# Altium schematic sheet Power Input and Protection Rev 5.SchDoc("Power Input and Protection Rev 5")
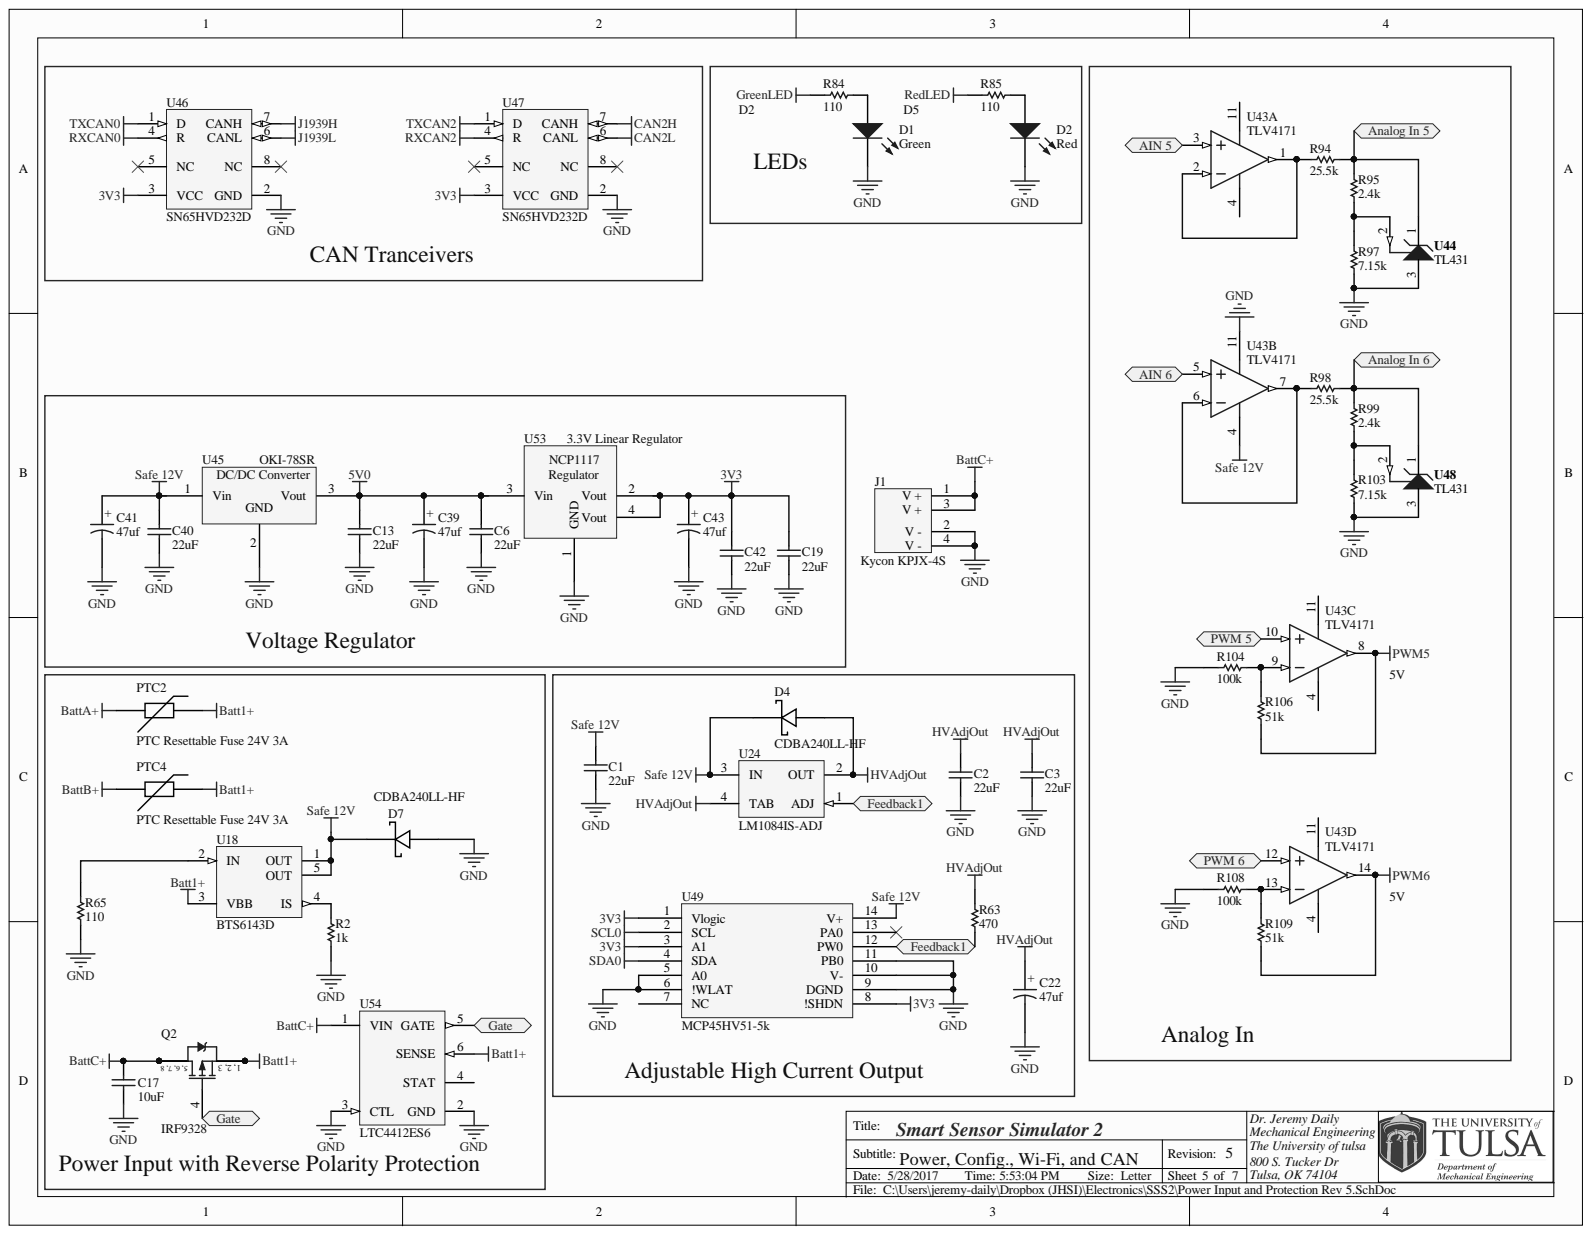
[diagram p0: Power Input and Protection Rev 5.SchDoc]

## Parts
C1  22uF  [1206]
C2  22uF  [1206]
C3  22uF  [1206]
C6  22uF  [1206]
C13  22uF  [1206]
C17  10uF  [0603]
C19  22uF  [1206]
C22  47uf  [Elec. Cap 2.5 x 6.3mm]
C39  47uf  [Elec. Cap 2.5 x 6.3mm]
C40  22uF  [1206]
C41  47uf  [Elec. Cap 2.5 x 6.3mm]
C42  22uF  [1206]
C43  47uf  [Elec. Cap 2.5 x 6.3mm]
D1  Green  [0805 Polarized]
D2  Red  [0805 Polarized]
D4  CDBA240LL-HF  [SMA with A and K]
D7  CDBA240LL-HF  [SMA with A and K]
J1  Kycon KPJX-4S  Manufacturer: Kycon  [KPJX-4S-S]
PTC2  PTC Resettable Fuse 24V 3A  [2920]
PTC4  PTC Resettable Fuse 24V 3A  [2920]
Q2  IRF9328  [SOIC-8]
R2  1k  [0603]
R63  470  [0603]
R65  110  [0603]
R84  110  [0603]
R85  110  [0603]
R94  25.5k  [0603]
R95  2.4k  [0603]
R97  7.15k  [0603]
R98  25.5k  [0603]
R99  2.4k  [0603]
R103  7.15k  [0603]
R104  100k  [0603]
R106  51k  [0603]
R108  100k  [0603]
R109  51k  [0603]
U18  BTS6143D  [INF-PG-TO252-5-11_N]
U24  LM1084IS-ADJ  [TS3B_M]
U43  TLV4171  [TI-PW14_M]  (parts A,B,C,D)
U44  TL431  [TI-DBZ3_N]
U45  OKI-78SR  [78xx]
U46  SN65HVD232D  [SN65HVD232]
U47  SN65HVD232D  [SN65HVD232]
U48  TL431  [TI-DBZ3_N]
U49  MCP45HV51-5k  [TSSOP-ST14_M]
U53  3.3V Linear Regulator  [SOT-223]
U54  LTC4412ES6  [LT-S6-6-TSOT-23_M]

## Ports
AIN 5, AIN 6, ANALOG IN 5, ANALOG IN 6, FEEDBACK1, GATE, PWM 5, PWM 6

## Nets
3V3: C19.2 C42.2 C43.1 U46.3(VCC) U47.3(VCC) U49.1(Vlogic) U49.3(A1) U49.8(!SHDN) U53.2(Vout) U53.4(Vout)
5V0: C6.2 C13.2 C39.1 U45.3 U53.3(Vin)
BATT1+: PTC2.2 PTC4.2 Q2.1 Q2.2 Q2.3 U18.3(VBB) U54.6(SENSE)
BATTA+: PTC2.1
BATTB+: PTC4.1
BATTC+: C17.1 J1.1(V_+) J1.3(V_+) Q2.5 Q2.6 Q2.7 Q2.8 U54.1(VIN)
CAN2H: U47.7(CANH)
CAN2L: U47.6(CANL)
GND: C1.1 C2.1 C3.1 C6.1 C13.1 C17.2 C19.1 C22.2 C39.2 C40.1 C41.2 C42.1 C43.2 D1.2 D2.2 D7.1 J1.2(V_-) J1.4(V_-) R2.1 R65.1 R97.1 R103.1 R104.2 R108.2 U43.11 U44.3 U45.2 U46.2(GND) U47.2(GND) U48.3 U49.5(A0) U49.6(!WLAT) U49.9(DGND) U49.10(V-) U49.11(PB0) U53.1(GND) U54.2(GND) U54.3(CTL)
GREENLED: R84.2
HVADJOUT: C2.2 C3.2 C22.1 D4.1 R63.1 U24.2(OUT) U24.4(TAB)
J1939H: U46.7(CANH)
J1939L: U46.6(CANL)
PWM5: R106.2 U43.8
PWM6: R109.2 U43.14
REDLED: R85.2
RXCAN0: U46.4(R)
RXCAN2: U47.4(R)
SAFE 12V: C1.2 C40.2 C41.1 D4.2 D7.2 U18.1(OUT) U18.5(OUT) U24.3(IN) U43.4 U45.1 U49.14(V+)
SCL0: U49.2(SCL)
SDA0: U49.4(SDA)
TXCAN0: U46.1(D)
TXCAN2: U47.1(D)
AIN 5: U43.3 ; port AIN 5
AIN 6: U43.5 ; port AIN 6
PWM 5: U43.10 ; port PWM 5
PWM 6: U43.12 ; port PWM 6
NetD1_1: D1.1 R84.1
NetD2_1: D2.1 R85.1
NetQ2_4: Q2.4 U54.5(GATE) ; port GATE
NetR2_2: R2.2 U18.4(IS)
NetR63_2: R63.2 U24.1(ADJ) U49.12(PW0) ; port FEEDBACK1
NetR65_2: R65.2 U18.2(IN)
NetR94_1: R94.1 U43.1 U43.2
NetR94_2: R94.2 R95.2 U44.1 ; port ANALOG IN 5
NetR95_1: R95.1 R97.2 U44.2
NetR98_1: R98.1 U43.6 U43.7
NetR98_2: R98.2 R99.2 U48.1 ; port ANALOG IN 6
NetR99_1: R99.1 R103.2 U48.2
NetR104_1: R104.1 R106.1 U43.9
NetR108_1: R108.1 R109.1 U43.13

Not connected: U46 5(NC) 8(NC); U47 5(NC) 8(NC); U49 7(NC) 13(PA0); U54 4(STAT)

## Library data of the parts
C1-C3 C6 C13 C19 C40 C42 = Cap: 22µF ±20% 25V Ceramic Capacitor X5R 1206 (3216 Metric); Manufacturer: Murata Electronics North America; PartNumber: GRT31CR61E226ME01L; Component Kind: Standard; Library Name: SSS Integrated Library.IntLib; Signal Integrity: Cap; Simulation: CAP; Supplier 1: Digi-Key; Supplier Part Number 1: 490-12451-1-ND
C17 = Cap: 10µF ±20% 25V Ceramic Capacitor X5R 0603 (1608 Metric); Manufacturer: Murata Electronics North America; PartNumber: GRT188R61E106ME13D; Component Kind: Standard; Library Name: SSS Integrated Library.IntLib; Signal Integrity: Cap; Simulation: CAP; Supplier 1: Digi-Key; Supplier Part Number 1: 490-12323-1-ND
C22 C39 C41 C43 = Electrolytic Cap: 47µF 50V Aluminum Capacitors Radial, Can 5000 Hrs @ 105°C; Manufacturer: Panasonic Electronic Components; PartNumber: EEU-EB1H470S; Component Kind: Standard; Library Name: SSS Integrated Library.IntLib; Supplier 1: Digi-Key; Supplier Part Number 1: P13464-ND
D1 = LED - Green: Green 570nm LED Indication - Discrete 2.2V 0805 (2012 Metric); Manufacturer: OSRAM Opto Semiconductors Inc.; PartNumber: LG R971-KN-1; Component Kind: Standard; Library Name: SSS Integrated Library.IntLib; Supplier 1: Digi-Key; Supplier Part Number 1: 475-1410-1-ND
D2 = LED - Red: Red 633nm LED Indication - Discrete 2V 0805 (2012 Metric); Manufacturer: OSRAM Opto Semiconductors Inc.; PartNumber: LS R976-NR-1; Component Kind: Standard; Library Name: SSS Integrated Library.IntLib; Supplier 1: Digi-Key; Supplier Part Number 1: 475-1278-1-ND
D4 D7 = Schottky Diode: Diode Schottky 40V 2A Surface Mount DO-214AC (SMA); Manufacturer: Comchip Technology; Component Kind: Standard; Library Name: SSS Integrated Library.IntLib; PartNumber: CDBA240LL-HF; Supplier 1: Digi-Key; Supplier Part Number 1: 641-1698-1-ND
J1 = Power Entry Jack - 4 Pin: DC Power Connectors 4P JACK SKT SHIELDED SNAP AND LOCK; Component Kind: Standard; Library Name: SSS Integrated Library.IntLib; PartNumber: KPJX-4S-S; Supplier 1: Mouser; Supplier Part Number 1: 806-KPJX-4S-S
PTC2 PTC4 = PTC: PTC Resettable Fuse 24V 3A Ih Surface Mount 2920 (7351 Metric), Concave; Manufacturer: Bourns Inc.; PartNumber: MF-LSMF300/24X-2; Component Kind: Standard; Library Name: SSS Integrated Library.IntLib; Supplier 1: Digi-Key; Supplier Part Number 1: MF-LSMF300/24X-2CT-ND
Q2 = IRF9328 P-FET: MOSFET P-CH 30V 12A 8-SOIC; Manufacturer: Infineon Technologies; PartNumber: IRF9328TRPBF; Component Kind: Standard; Library Name: SSS Integrated Library.IntLib; Supplier 1: Digi-Key; Supplier Part Number 1: IRF9328TRPBFCT-ND
R2 = Chip Resistor: RES SMD 1K OHM 1% 1/10W 0603; Manufacturer: Panasonic Electronic Components; PartNumber: ERJ-3EKF1001V; Component Kind: Standard; Library Name: SSS Schematic Library.SchLib; Supplier 1: Digi-Key; Supplier Part Number 1: P1.00KHCT-ND
R63 = Chip Resistor: RES SMD 110 OHM 1% 1/10W 0603; Manufacturer: Panasonic Electronic Components; PartNumber: ERJ-3EKF4700V; Component Kind: Standard; Library Name: SSS Integrated Library.IntLib; Supplier 1: Digi-Key; Supplier Part Number 1: P470HCT-ND
R65 R84 R85 = Chip Resistor: RES SMD 110 OHM 1% 1/10W 0603; Manufacturer: Panasonic Electronic Components; PartNumber: ERJ-3EKF1100V; Component Kind: Standard; Library Name: SSS Integrated Library.IntLib; Supplier 1: Digi-Key; Supplier Part Number 1: P110HCT-ND
R94 R98 = Chip Resistor: RES SMD 25.5K OHM 1% 1/10W 0603; Manufacturer: Panasonic Electronic Components; PartNumber: ERJ-3EKF2552V; Component Kind: Standard; Library Name: SSS Integrated Library.IntLib; Supplier 1: Digi-Key; Supplier Part Number 1: P25.5KHCT-ND
R95 R99 = Chip Resistor: RES SMD 2.4K OHM 1% 1/10W 0603; Manufacturer: Panasonic Electronic Components; PartNumber: ERJ-3EKF2401V; Component Kind: Standard; Library Name: SSS Integrated Library.IntLib; Supplier 1: Digi-Key; Supplier Part Number 1: P2.40KHCT-ND
R97 R103 = Chip Resistor: RES SMD 7.15K OHM 1% 1/10W 0603; Manufacturer: Panasonic Electronic Components; PartNumber: ERJ-3EKF7151V; Component Kind: Standard; Library Name: SSS Integrated Library.IntLib; Supplier 1: Digi-Key; Supplier Part Number 1: P7.15KHCT-ND
R104 R108 = Chip Resistor: RES SMD 100K OHM 1% 1/10W 0603; Manufacturer: Panasonic Electronic Components; PartNumber: ERJ-3EKF1003V; Component Kind: Standard; Library Name: SSS Integrated Library.IntLib; Supplier 1: Digi-Key; Supplier Part Number 1: P100KHCT-ND
R106 R109 = Chip Resistor: RES SMD 51K OHM 1% 1/10W 0603; Manufacturer: Panasonic Electronic Components; PartNumber: ERJ-3EKF5102V; Component Kind: Standard; Library Name: SSS Integrated Library.IntLib; Supplier 1: Digi-Key; Supplier Part Number 1: P51.0KHCT-ND
U18 = BTS6143D: PROFET Smart Highside Power Switch, 1 Channel, 10 A Load Current, -40 to 150 degC, PG-TO252-5-11, Reel, Green; Manufacturer: Infineon; Component Kind: Standard; Library Name: Infineon_Power_Smart_Low-Side_&_High-Side_Switches.IntLib; PartNumber: BTS6143D; Supplier 1: Digi-Key; Supplier Part Number 1: BTS6143DINCT-ND
U24 = LM1084IS-ADJ: Linear Voltage Regulator IC Positive Adjustable 1 Output 1.2 V ~ 15 V 5A DDPAK/TO-263-3; Manufacturer: Texas Instruments; PartNumber: LM1084IS-ADJ/NOPB; Component Kind: Standard; Library Name: National Semiconductor LDO.IntLib; Supplier 1: Digi-Key; Supplier Part Number 1: LM1084IS-ADJ/NOPB-ND
U43 = TL064CPW: Quad Low-Power JFET-Input General-Purpose Operational Amplifier, 7 to 36 V, 0 to 70 degC, 14-pin SOP (PW14), Green (RoHS & no Sb/Br); Manufacturer: Texas Instruments; PartNumber: TLV4171IPWR; Component Kind: Standard; Library Name: TI Amplifiers and Linear Operational Amplifier General Purpose.IntLib; Supplier 1: Digi-Key; Supplier Part Number 1: 296-44866-1-ND
U44 U48 = TL431AIDBZR: Adjustable Precision Shunt Regulator, 34 ppm / degC, 100 mA, -40 to 85 degC, 3-pin SOT-23 (DBZ), Green (RoHS & no Sb/Br); Manufacturer: Texas Instruments; Component Kind: Standard; Library Name: TI Power Management Shunt Voltage Reference.IntLib; PartNumber: TL431AIDBZR; Supplier 1: Digi-Key; Supplier Part Number 1: 296-17329-2-ND
U45 = 7805: Linear Regulator Replacement DC DC Converter 1 Output 5V 1.5A 7V - 36V Input; Manufacturer: Murata Power Solutions; PartNumber: OKI-78SR-5/1.5-W36-C; Component Kind: Standard; Library Name: SSS Integrated Library.IntLib; Supplier 1: Digi-Key; Supplier Part Number 1: 811-2692-ND
U46 U47 = SN65HVD232D: 3.3 V CAN Transceiver, 17 mA, -40 to 85 degC, 8-pin SOIC (D), Green (RoHS & no Sb/Br); Manufacturer: Texas Instruments; Component Kind: Standard; Library Name: Texas Instruments Interface CAN.IntLib; PartNumber: SN65HVD232D; Supplier 1: Digi-Key; Supplier Part Number 1: 296-12282-5-ND
U49 = MCP45HVX1 Digital Pot: Digital Potentiometer 5k Ohm 1 Circuit 256 Taps I²C Interface 14-TSSOP; Manufacturer: Microchip Technology; PartNumber: MCP45HV51-502E/ST; Component Kind: Standard; Library Name: SSS Integrated Library.IntLib; Supplier 1: Digi-Key; Supplier Part Number 1: MCP45HV51-502E/ST-ND
U53 = NCP1117 2.5V: Linear Voltage Regulator IC Positive Fixed 1 Output 3.3V 1A SOT-223; Manufacturer: ON Semiconductor; PartNumber: NCP1117ST33T3G; Component Kind: Standard; Library Name: SSS Integrated Library.IntLib; Supplier 1: Digi-Key; Supplier Part Number 1: NCP1117ST33T3GOSCT-ND
U54 = LTC4412ES6: Low Loss PowerPath(TM) Controller, 2.5 to 28 V Vin, 6-pin SOT23 (S6-6), -40 to 85 degC; Manufacturer: Linear Technology; Component Kind: Standard; Library Name: Linear Technology Power Management Power Control.IntLib; PartNumber: LTC4412ES6; Supplier 1: Linear Technology; Supplier Part Number 1: LTC4412ES6#TRPBFCT-ND
